# Altium schematic sheet KETCube.SchDoc
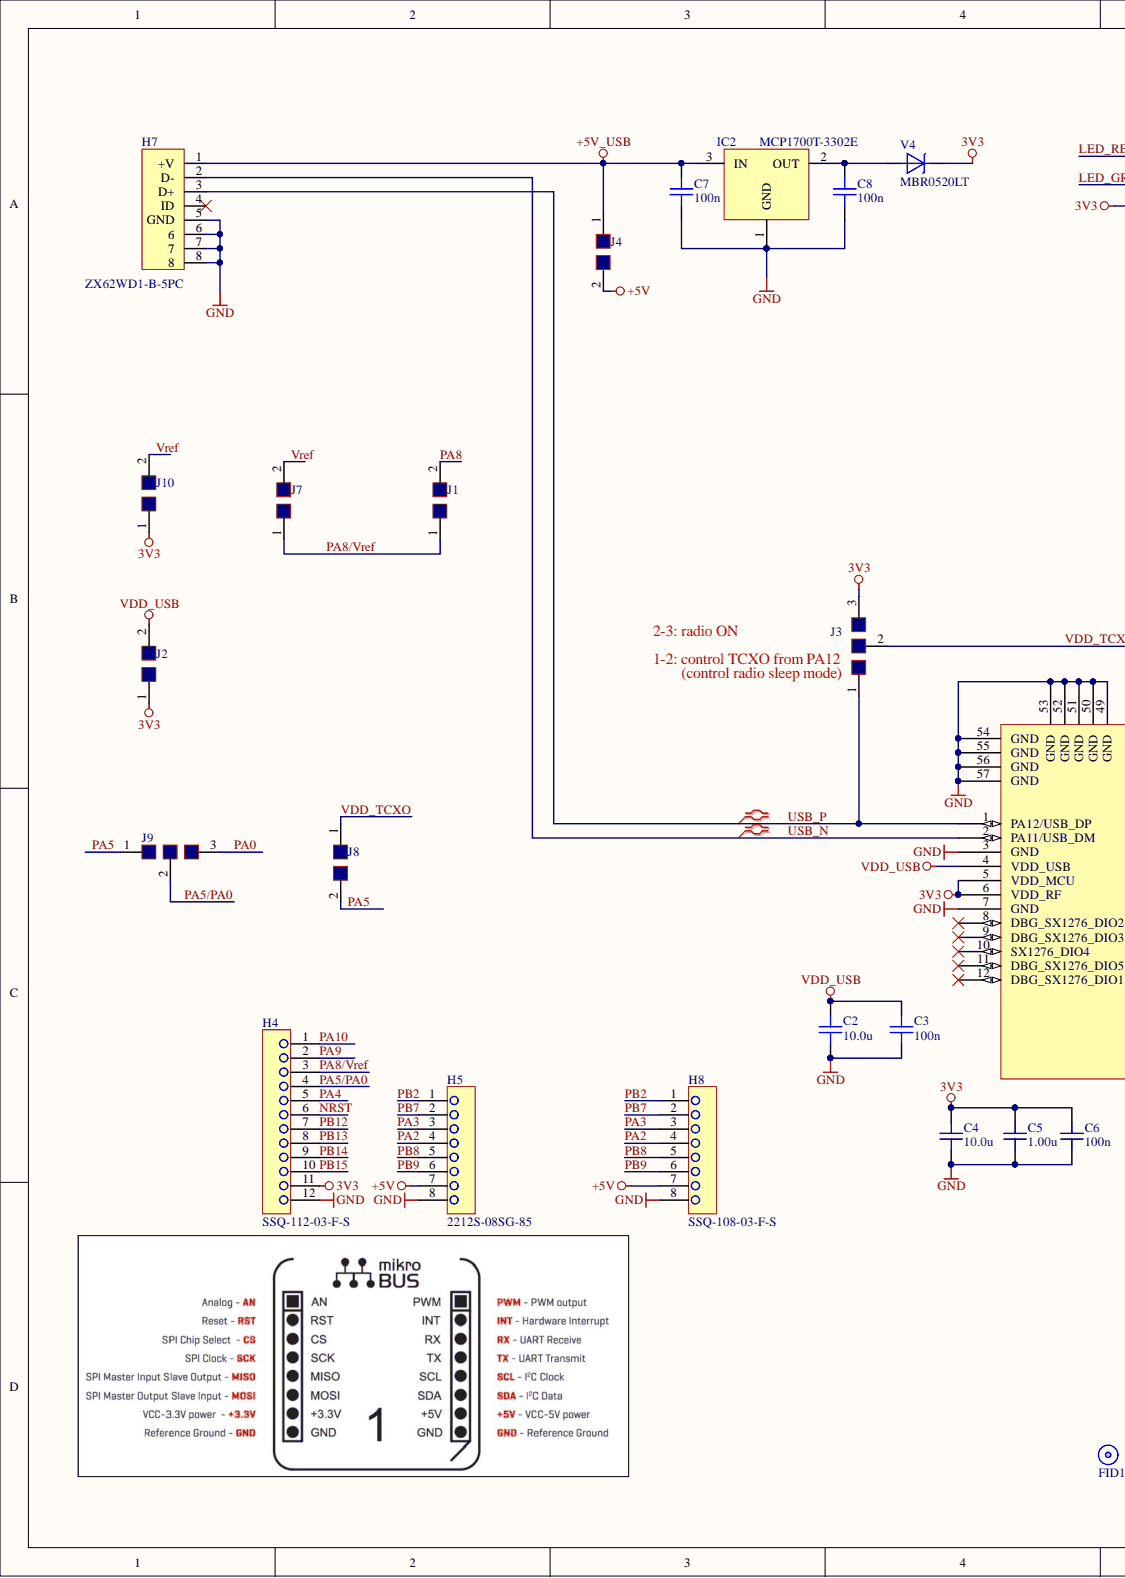
[diagram p0: KETCube.SchDoc, left]
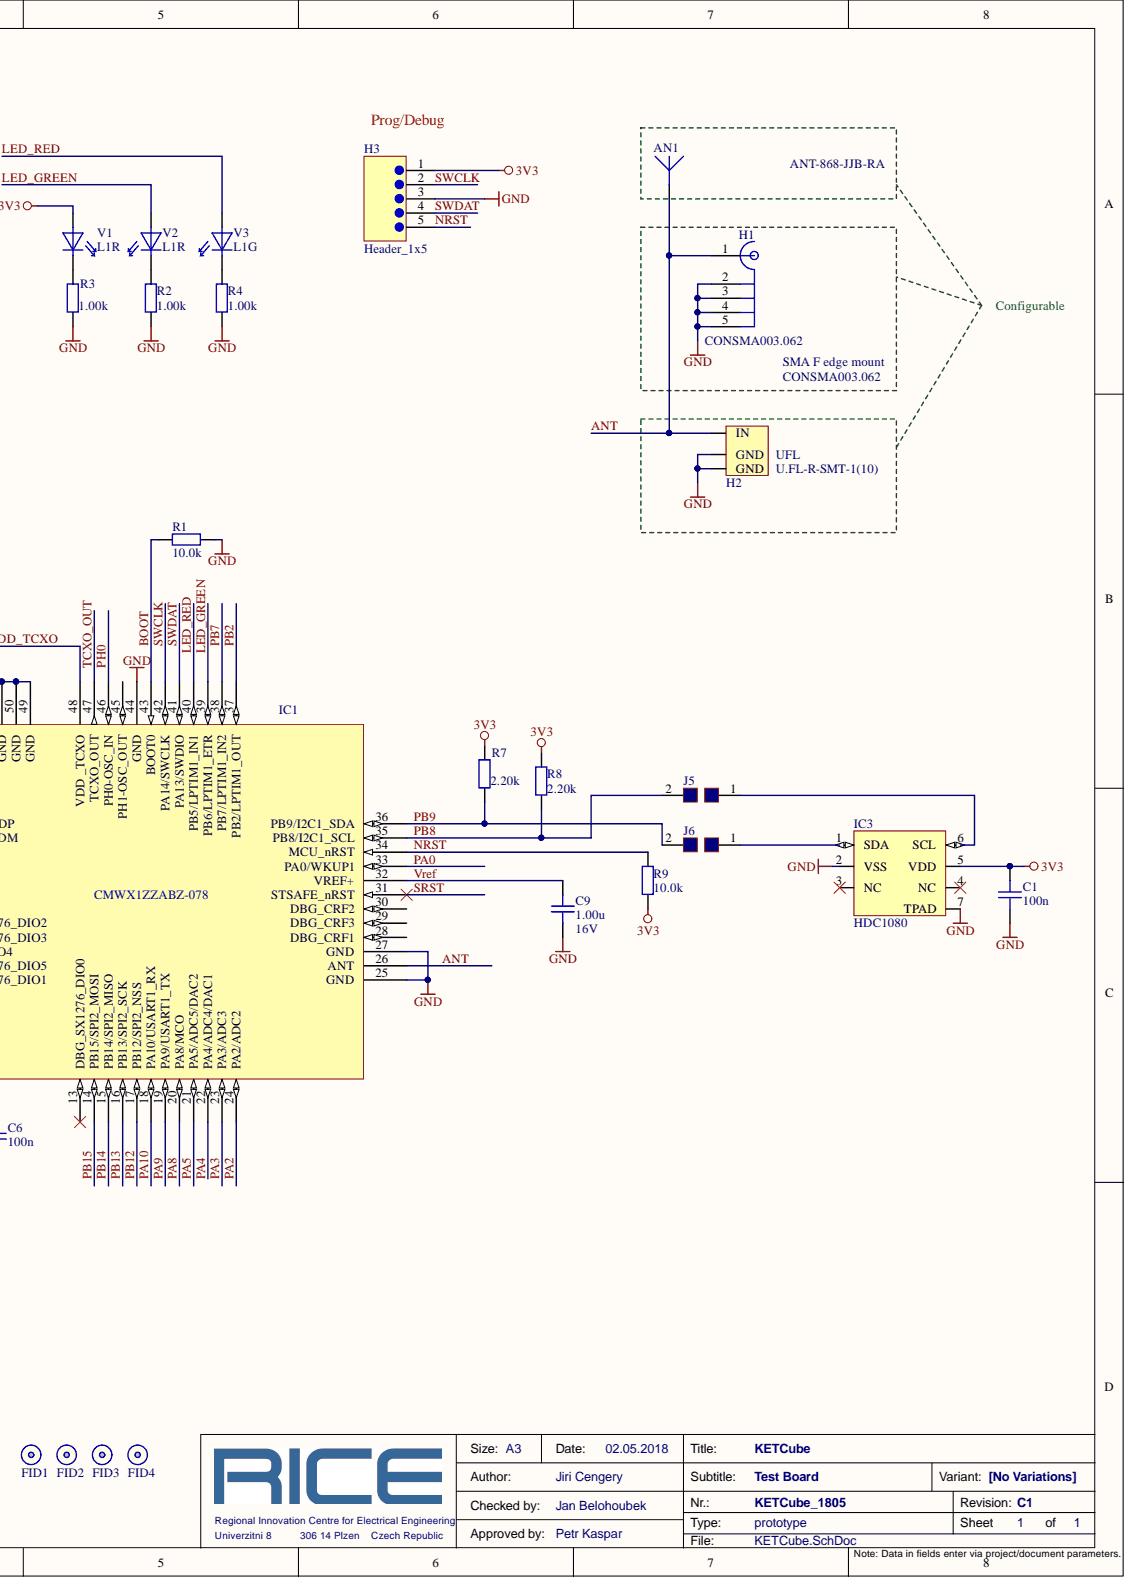
[diagram p1: KETCube.SchDoc, right]

## Parts
AN1  ANT-868-JJB-RA  [TH_ANT_868MHZ_90DEG]
C1  100n  [sm_cap_0603]
C2  10.0u  [sm_cap_0805]
C3  100n  [sm_cap_0603]
C4  10.0u  [sm_cap_0805]
C5  1.00u  [sm_cap_0603]
C6  100n  [sm_cap_0603]
C7  100n  [sm_cap_0603]
C8  100n  [sm_cap_0603]
C9  1.00u  Voltage: 16V  [sm_cap_0603]
FID1  Fiducial  [SM_mch_FIDUCIAL_32]  (value not drawn)
FID2  Fiducial  [SM_mch_FIDUCIAL_32]  (value not drawn)
FID3  Fiducial  [SM_mch_FIDUCIAL_32]  (value not drawn)
FID4  Fiducial  [SM_mch_FIDUCIAL_32]  (value not drawn)
H1  CONSMA003.062  [SM_CON_SMA_F_EDGE_LINX]  (value not drawn)
H2  U.FL-R-SMT-1  Manuf Part Number: U.FL-R-SMT-1(10)  [SM_CON_U.FL_R_SMT_1]
H3  Header_1x5  [TH_CON_HDR1X5_PIT50_RIGHT]
H4  SSQ-112-03-F-S  [TH_CON_REC1x12_PIT100_L400]
H5  2212S-08SG-85  [TH_CON_REC1x8_PIT100]
H7  ZX62WD1-B-5PC  [SM_CON_USB_Micro_B_ZX62WD1]
H8  SSQ-108-03-F-S  [TH_CON_REC1x8_PIT100_L400]
IC1  CMWX1ZZABZ-078  [TH_MOD_PIN48_LoRa_Murata]
IC2  MCP1700T-3302E  [sm_io_sot23_pin3]
IC3  HDC1080  [SM_IO_WSON6_PIT40]
J1  Solder jumper 0603  [SM_SOLDER_JUMPER_2PIN_0603]  (value not drawn)  (no BOM)
J2  Solder jumper 0603  [SM_SOLDER_JUMPER_2PIN_0603]  (value not drawn)  (no BOM)
J3  SMD jumper  [SM_SOLDER_JUMPER_3PIN]  (value not drawn)  (no BOM)
J4  Solder jumper 0603  [SM_SOLDER_JUMPER_2PIN_0603]  (value not drawn)  (no BOM)
J5  Solder jumper 0603  [SM_SOLDER_JUMPER_2PIN_0603]  (value not drawn)  (no BOM)
J6  Solder jumper 0603  [SM_SOLDER_JUMPER_2PIN_0603]  (value not drawn)  (no BOM)
J7  Solder jumper 0603  [SM_SOLDER_JUMPER_2PIN_0603]  (value not drawn)  (no BOM)
J8  Solder jumper 0603  [SM_SOLDER_JUMPER_2PIN_0603]  (value not drawn)  (no BOM)
J9  SMD jumper  [SM_SOLDER_JUMPER_3PIN]  (value not drawn)  (no BOM)
J10  Solder jumper 0603  [SM_SOLDER_JUMPER_2PIN_0603]  (value not drawn)  (no BOM)
R1  10.0k  [sm_res_0603]
R2  1.00k  [sm_res_0603]
R3  1.00k  [sm_res_0603]
R4  1.00k  [sm_res_0603]
R7  2.20k  [sm_res_0603]
R8  2.20k  [sm_res_0603]
R9  10.0k  [sm_res_0603]
V1  L1R  [sm_opt_led0603]
V2  L1R  [sm_opt_led0603]
V3  L1G  [sm_opt_led0603]
V4  MBR0520LT  [SM_DIO_SOD123]

## Nets
3V3: C1.2 C4.2 C5.2 C6.2 H3.1 H4.11 IC1.5(VDD_MCU) IC1.6(VDD_RF) IC3.5(VDD) J2.1 J3.3 J10.1 R7.2 R8.2 R9.1 V1.1 V4.2
+5V: H5.7 H8.7 J4.2
+5V_USB: C7.2 H7.1(+V) IC2.3 J4.1
GND: C1.1 C2.1 C3.1 C4.1 C5.1 C6.1 C7.1 C8.1 C9.1 H1.2 H1.3 H1.4 H1.5 H2.2 H2.3 H3.3 H4.12 H5.8 H7.5(GND) H7.6 H7.7 H7.8 H8.8 IC1.3(GND) IC1.7(GND) IC1.25(GND) IC1.27(GND) IC1.44(GND) IC1.49(GND) IC1.50(GND) IC1.51(GND) IC1.52(GND) IC1.53(GND) IC1.54(GND) IC1.55(GND) IC1.56(GND) IC1.57(GND) IC2.1 IC3.2(VSS) IC3.7(TPAD) R1.1 R2.2 R3.1 R4.2
VDD_USB: C2.2 C3.2 IC1.4(VDD_USB) J2.2
ANT: AN1.1 H1.1 H2.1 IC1.26(ANT)
BOOT: IC1.43(BOOT0) R1.2
LED_GREEN: IC1.39(PB6/LPTIM1_ETR) V2.1
LED_RED: IC1.40(PB5/LPTIM1_IN1) V3.1
NRST: H3.5 H4.6 IC1.34(MCU_nRST) R9.2
PA0: IC1.33(PA0/WKUP1) J9.3
PA2: H5.4 H8.4 IC1.24(PA2/ADC2)
PA3: H5.3 H8.3 IC1.23(PA3/ADC3)
PA4: H4.5 IC1.22(PA4/ADC4/DAC1)
PA5: IC1.21(PA5/ADC5/DAC2) J8.2 J9.1
PA5/PA0: H4.4 J9.2
PA8: IC1.20(PA8/MCO) J1.2
PA8/Vref: H4.3 J1.1 J7.1
PA9: H4.2 IC1.19(PA9/USART1_TX)
PA10: H4.1 IC1.18(PA10/USART1_RX)
PB2: H5.1 H8.1 IC1.37(PB2/LPTIM1_OUT)
PB7: H5.2 H8.2 IC1.38(PB7/LPTIM1_IN2)
PB8: H5.5 H8.5 IC1.35(PB8/I2C1_SCL) J5.2 R8.1
PB9: H5.6 H8.6 IC1.36(PB9/I2C1_SDA) J6.2 R7.1
PB12: H4.7 IC1.17(PB12/SPI2_NSS)
PB13: H4.8 IC1.16(PB13/SPI2_SCK)
PB14: H4.9 IC1.15(PB14/SPI2_MISO)
PB15: H4.10 IC1.14(PB15/SPI2_MOSI)
PH0: IC1.46(PH0-OSC_IN)
SRST: IC1.31(STSAFE_nRST)
SWCLK: H3.2 IC1.42(PA14/SWCLK)
SWDAT: H3.4 IC1.41(PA13/SWDIO)
TCXO_OUT: IC1.47(TCXO_OUT)
USB_N: H7.2(D-) IC1.2(PA11/USB_DM)
USB_P: H7.3(D+) IC1.1(PA12/USB_DP) J3.1
VDD_TCXO: IC1.48(VDD__TCXO) J3.2 J8.1
Vref: C9.2 IC1.32(VREF+) J7.2 J10.2
NetC8_2: C8.2 IC2.2 V4.1
NetIC3_1: IC3.1(SDA) J6.1
NetIC3_6: IC3.6(SCL) J5.1
NetR2_1: R2.1 V2.2
NetR3_2: R3.2 V1.2
NetR4_1: R4.1 V3.2

Not connected: H7 4(ID); IC1 8(DBG_SX1276_DIO2) 9(DBG_SX1276_DIO3) 10(SX1276_DIO4) 11(DBG_SX1276_DIO5) 12(DBG_SX1276_DIO1) 13(DBG_SX1276_DIO0) 28(DBG_CRF1) 29(DBG_CRF3) 30(DBG_CRF2) 45(PH1-OSC_OUT); IC3 3(NC) 4(NC)

## Library data of the parts
AN1 = MOD_000026: MOD Antenna, PCB mount, Perm Mnt Mini, 90deg, 868MHz; Manufacturer: Linx Technologies; ManufacturerID: Linx; Part Select: TMOD0006000000000000; Supp Part number: 712-ANT-868-JJB-RA; Active: 1; Database Table Name: _Modules; Designer: Lukas Pusman; Exact price 2: 0; FIT: 3; ID: 4530; LasrRevisionNote: change designator to AN; Max Oper Temperature: 90; Min Oper Temperature: -40; Alternative: 1; Cat1: Antenna; Component Kind: Standard; ComponentLink1Description: Datasheet; ComponentLink1URL: https://riceproject.fel.zcu.cz/Altium/PDF/Passive\antennas\Linx\ANT-868-JJB-xx_DataSheet_131209-291227.pdf; ComponentLink2Description: PCB Antenna; Exact price: 1; Exaxt price 3: 0; LastRevisionDate: 2.7.2013; Library Name: Lib_Components_SQL.DbLib; ManufacturerURL: https://www.linxtechnologies.com; Manuf Part Number: ANT-868-JJB-RA; Part Category: Antenna; Part Number: MOD_000026; PartNumber: MOD_000026; PartQuantity: 1; Part type: MOD; Preference: 0; Price: 54; Price 2: 0; Price 3: 0; Publishied: 11.6.2013; ROHS: 1; Simulation: nosim; Stock: 0; Supplier: Mouser Electronics; Supplier2: N/A; Supplier3: N/A; SupplierID: Mouser; SupplierID2: no supplier; SupplierID3: no supplier; SupplierURL: www.mouser.com; SupplierURL2: N/A; SupplierURL3: N/A; Value: ANT-868-JJB-RA; Publisher: Jiri Zahour
C1 C3 C6-C8 = CAP_000000: CAP CER .10UF 50V X7R 10% 0603; Manufacturer: TDK Corporation; ManufacturerID: TDK; Manuf Part Number: C1608X7R1H104K080AA; Part Category: Ceramic/0603/X7R; Part Select: SCAP0001000300026100; Supp Part number: 445-1314-2-ND; Supp Part number 2: 1907318; Active: 1; Cat1: Ceramic; Cat2: 0603; Database Table Name: _Capacitors; Designer: Jiri  Cengery; Exact price 2: 0; FIT: 0,100000001490116; ID: 4; LasrRevisionNote: new release; Max Oper Temperature: 125; Min Oper Temperature: -55; PCB Status: Electrical; Preference: 100; Alternative: 1; Cat3: X7R; Component Kind: Standard; ComponentLink1Description: Datasheet; ComponentLink1URL: https://riceproject.fel.zcu.cz/Altium/PDF/passive\capacitors\Cxxxx_TDK.pdf; Dielectric: X7R; Exact price: 1; Exaxt price 3: 0; LastRevisionDate: 11.5.2011; Library Name: Lib_Components_SQL.DbLib; ManufacturerURL: http://www.tdk.com/; Part Number: CAP_000000; PartNumber: CAP_000000; PartQuantity: 1; Part type: CAP; PCB3D: sm_cap_0603; Price: 0,112099997699261; Price 2: 0,899999976158142; Price 3: 0; Publishied: 11.5.2011; Quantity: 1; ROHS: 1; Simulation: cap; Stock: 2901; Supplier: Digikey; Supplier2: Farnell; Supplier3: N/A; SupplierID: Digikey; SupplierID2: Farnell; SupplierID3: no supplier; SupplierURL: http://www.digikey.com/; SupplierURL2: http://cz.farnell.com/; SupplierURL3: N/A; Tolerance: 10%; Value: 100n; Voltage: 50V; Publisher: Libor Polacek
C2 C4 = CAP_000438: CAP CER 10.0u 16V X6S 10% 105°C 0805; Manufacturer: TDK Corporation; ManufacturerID: TDK; Manuf Part Number: C2012X6S1C106K125AC; Part Category: Ceramic/0805/X6S; Part Select: SCAP0001000400038100; Supp Part number: 2346933; Supp Part number 2: 810-C2012X6S1C106K1C; Supp Part number 3: 445-7611-1-ND; Active: 1; Cat1: Ceramic; Cat2: 0805; Database Table Name: _Capacitors; Designer: Jindrich Krivka; FIT: 0,100000001490116; ID: 2834; LastRevisionNote: new release; Max Oper Temperature: 105; Min Oper Temperature: -55; PCB Status: Electrical; Preference: 0; Alternative: 1; Cat3: X6S; Component Kind: Standard; ComponentLink1Description: Datasheet; ComponentLink1URL: https://riceproject.fel.zcu.cz/Altium/PDF/Passive\Capacitors\TDK_mlcc_C_Comercial_Grade.pdf; Dielectric: X6S; Exact price: 1; Exact price 2: 1; Exaxt price 3: 1; LastRevisionDate: 11.5.2011; Library Name: Lib_Components_SQL.DbLib; ManufacturerURL: http://www.tdk.com/; Part Number: CAP_000438; PartNumber: CAP_000438; PartQuantity: 1; Part type: CAP; Price: 5,67999982833862; Price 2: 8,60000038146973; Price 3: 8,30000019073486; Published: 11.5.2011; ROHS: 1; Simulation: cap; Stock: 0; Supplier: Farnell; Supplier2: Mouser Electronics; Supplier3: Digikey; SupplierID: Farnell; SupplierID2: Mouser; SupplierID3: Digikey; SupplierURL: http://cz.farnell.com/; SupplierURL2: www.mouser.com; SupplierURL3: http://www.digikey.com/; Tolerance: 10%; Value: 10.0u; Voltage: 16V; Publisher: Libor Polacek
C5 C9 = CAP_000381: CAP CER 1.0UF 16V X7R 10% 0603; Manufacturer: TDK Corporation; ManufacturerID: TDK; Manuf Part Number: C1608X7R1C105K; Part Category: Ceramic/0603/X7R; Part Select: SCAP0001000300027100; Supp Part number: 445-1604-1-ND; Supp Part number 2: 1907343; Active: 1; Cat1: Ceramic; Cat2: 0603; Database Table Name: _Capacitors; Designer: Jiri  Cengery; Exact price 2: 0; FIT: 0,100000001490116; ID: 1567; LastRevisionNote: new release; Max Oper Temperature: 125; Min Oper Temperature: -55; PCB Status: Electrical; Alternative: 1; Cat3: X7R; Component Kind: Standard; ComponentLink1Description: Datasheet; ComponentLink1URL: https://riceproject.fel.zcu.cz/Altium/PDF/Passive\Capacitors\C_series_TDK.pdf; Dielectric: X7R; Exact price: 1; Exaxt price 3: 0; LastRevisionDate: 11.5.2011; Library Name: Lib_Components_SQL.DbLib; ManufacturerURL: http://www.tdk.com/; Part Number: CAP_000381; PartNumber: CAP_000381; PartQuantity: 1; Part type: CAP; PCB3D: sm_cap_0603; Preference: 0; Price: 1,10000002384186; Price 2: 25; Price 3: 0; Published: 11.5.2011; ROHS: 1; Simulation: cap; Stock: 3184; Supplier: Digikey; Supplier2: Farnell; Supplier3: N/A; SupplierID: Digikey; SupplierID2: Farnell; SupplierID3: no supplier; SupplierURL: http://www.digikey.com/; SupplierURL2: http://cz.farnell.com/; SupplierURL3: N/A; Tolerance: 10%; Value: 1.00u; Publisher: Libor Polacek
  C5: Voltage: 16V
FID1-FID4 = MCH_000050: Fiducial local circle 0.8mm; ManufacturerID: no manufacturer; Manuf Part Number: x; Part Category: Virtual/Fiducial; Part Select: SMCH0002000200000000; Active: 1; Cat1: Virtual; Database Table Name: _Mechanicals; Designer: Libor Polacek; Exact price: 0; ID: 2608; LastRevisionNote: new release; Max Oper Temperature: 200; Min Oper Temperature: -60; Alternative: 1; Cat2: Fiducial; Component Kind: Standard; ComponentLink1Description: Datasheet; Exact price 2: 0; Exaxt price 3: 0; FIT: 0; Function Type: fiducial; LastRevisionDate: 8.9.2011; Library Name: Lib_Components_SQL.DbLib; Part Number: MCH_000050; PartNumber: MCH_000050; PartQuantity: 1; Part type: MCH; PCB3D: sm_vir_fiducial; PCB Status: Virtual; Preference: 0; Price: 0; Price 2: 0; Price 3: 0; Publishied: 8.9.2011; ROHS: 1; Simulation: nosim; Stock: 0; Supplier: N/A; Supplier2: N/A; Supplier3: N/A; SupplierID: no supplier; SupplierID2: no supplier; SupplierID3: no supplier; SupplierURL: N/A; SupplierURL2: N/A; SupplierURL3: N/A; Supp Part number: x; Value: Fiducial; Publisher: Libor Polacek
H1 = CON_000304: SMA; Manufacturer: Lynx Technologies; Part Select: SCON0008000000001500; Supp Part number: CONSMA003.062-ND; Supp Part number 2: 712-CONSMA003.062; Active: 1; Cat1: VF; Database Table Name: _Connectors; Design: SMA Female  edge mount; Designer: Jiri  Cengery; Exact price 2: 0; ID: 4582; LastRevisionNote: new release; Max Oper Temperature: 165; Min Oper Temperature: -65; PCB Status: Electrical; Alternative: 1; Component Kind: Standard; ComponentLink1Description: Datasheet; ComponentLink1URL: https://riceproject.fel.zcu.cz/Altium/PDF/Mechanic\CONSMA003_062.pdf; ComponentLink2Description: SMA connector; Exact price: 1; Exaxt price 3: 0; FIT: 0; LastRevisionDate: 11.11.2013; Library Name: Lib_Components_SQL.DbLib; ManufacturerID: Lynx Technologies; ManufacturerURL: www.linxtechnologies.com; Manuf Part Number: CONSMA003.062; Part Category: VF; Part Number: CON_000304; PartNumber: CON_000304; PartQuantity: 1; Part type: CON; Pin: 5; Preference: 0; Price: 75; Price 2: 84; Price 3: 0; Published: 29.5.2013; ROHS: 1; Simulation: nosim; Stock: 0; Supplier: Digikey; Supplier2: N/A; Supplier3: N/A; SupplierID: Digikey; SupplierID2: no supplier; SupplierID3: no supplier; SupplierURL: http://www.digikey.com/; SupplierURL2: N/A; SupplierURL3: N/A; Value: CONSMA003.062; Publisher: Jiri Svarny
H2 = CON_000300: CON 3pin, Ultra Small Surface Mount Coaxial Connectors, up to 6GHz; Manufacturer: Hirose U.S.A.; ManufacturerID: Hirose; Part Select: SCON0008000000001300; Supp Part number: 1688077; Active: 1; Cat1: VF; Database Table Name: _Connectors; Design: smt; Designer: Jiri  Cengery; Exact price 2: 0; ID: 4492; LastRevisionNote: new realese; Max Oper Temperature: 120; Min Oper Temperature: -30; PCB Status: Electrical; Alternative: 1; Component Kind: Standard; ComponentLink1Description: Datasheet; ComponentLink1URL: https://riceproject.fel.zcu.cz/Altium/PDF/Passive\Connectors\Hirose\1947917.pdf; Exact price: 1; Exaxt price 3: 0; FIT: 0; LastRevisionDate: 1.9.2016; Library Name: Lib_Components_SQL.DbLib; ManufacturerURL: http://www.hiroseusa.com/; Part Category: VF; Part Number: CON_000300; PartNumber: CON_000300; PartQuantity: 1; Part type: CON; Pin: 3; Preference: 0; Price: 18; Price 2: 0; Price 3: 0; Published: 1.9.2016; ROHS: 1; Simulation: nosim; Stock: 0; Supplier: Farnell; Supplier2: N/A; Supplier3: N/A; SupplierID: Farnell; SupplierID2: no supplier; SupplierID3: no supplier; SupplierURL: http://cz.farnell.com/; SupplierURL2: N/A; SupplierURL3: N/A; Value: U.FL-R-SMT-1; Publisher: Jiri Cengery
H3 = CON_000371: CON 1x5pin header 50mill, righ , L 4mm; Manufacturer: SAMTEC; Manuf Part Number: TMS-105-02-L-S-RA; Part Category: Pin rows/Header PIT50/Position; Part Select: TCON0001000600015001; Supp Part number: 2055114; Active: 1; Cat1: Pin rows; Cat2: Header PIT50; Cat3: Position; Database Table Name: _Connectors; Designer: Jiri  Cengery; Exact price 2: 0; FIT: 5; ID: 5632; Max Oper Temperature: 105; Min Oper Temperature: -40; PCB Status: Electrical; Alternative: 1; Component Kind: Standard; ComponentLink1Description: Datasheet; ComponentLink1URL: https://riceproject.fel.zcu.cz/Altium/PDF/Passive\Connectors\Samtec\1992060.pdf; Design: HEADER 50mill; Exact price: 1; Exaxt price 3: 0; LatestRevisionDate: 09-Sep-2011; LatestRevisionNote: Re-released for DXP Platform.; Library Name: Lib_Components_SQL.DbLib; ManufacturerID: SAMTEC; ManufacturerURL: http://www.samtec.com/; Part Number: CON_000371; PartNumber: CON_000371; PartQuantity: 1; Part type: CON; Pin: 5; Preference: 0; Price: 14; Price 2: 0; Price 3: 0; Published: 15.6.2011; ROHS: 1; Signal Integrity: Connector; Simulation: nosim; Stock: 0; Supplier: Farnell; Supplier2: N/A; Supplier3: N/A; SupplierID: Farnell; SupplierID2: no supplier; SupplierID3: no supplier; SupplierURL: http://cz.farnell.com/; SupplierURL2: N/A; SupplierURL3: N/A; Value: Header_1x5; Publisher: Altium Limited
H4 = CON_000361: CON Receptacle 1x10 100 mils, leads length 10mm; Manufacturer: SAMTEC; Part Category: Pin rows/Receptacle/long leads; Part Select: TCON0001000200022120; Supp Part number: 2283784; Active: 1; Cat1: Pin rows; Cat3: long leads; Database Table Name: _Connectors; Design: RECEPTACLE 100mill; Designer: Jiri  Cengery; Exact price 2: 0; FIT: 50; ID: 5578; Max Oper Temperature: 125; Min Oper Temperature: -55; PCB Status: Electrical; System: Connector; Alternative: 1; Cat2: Receptacle; Component Kind: Standard; ComponentLink1Description: Datasheet; ComponentLink1URL: https://riceproject.fel.zcu.cz/Altium/PDF/Passive\Connectors\Samtec\1680445_.pdf; Exact price: 1; Exaxt price 3: 0; LatestRevisionDate: 13.10.2017; LatestRevisionNote: New Release; Library Name: Lib_Components_SQL.DbLib; ManufacturerID: SAMTEC; ManufacturerURL: http://www.samtec.com/; Manuf Part Number: SSQ-112-03-F-S; Part Number: CON_000361; PartNumber: CON_000361; PartQuantity: 1; Part type: CON; PCB3D: TH_CON_REC1x12_PIT100_L400; Pin: 12; Preference: 0; Price: 54; Price 2: 0; Price 3: 0; Published: 13.10.2017; ROHS: 1; Signal Integrity: Connector; Simulation: nosim; Stock: 0; Supplier: Farnell; Supplier2: N/A; Supplier3: N/A; SupplierID: Farnell; SupplierID2: no supplier; SupplierID3: no supplier; SupplierURL: http://cz.farnell.com/; SupplierURL2: N/A; SupplierURL3: N/A; Value: SSQ-112-03-F-S; Publisher: Jiri Cengery
H5 = CON_000370: CON Receptacle 1x8 100 mils, hight 8.5mm; Manufacturer: MCM Electronics; ManufacturerID: Multicomp; Part Category: Pin rows/Receptacle; Part Select: TCON0001000200001800; Supp Part number: 1593463; Active: 1; Cat1: Pin rows; Database Table Name: _Connectors; Design: RECEPTACLE 100mill; Designer: Jiri  Cengery; Exact price 2: 0; FIT: 50; ID: 5624; Max Oper Temperature: 105; Min Oper Temperature: -55; PCB Status: Electrical; System: Connector; Alternative: 1; Cat2: Receptacle; Component Kind: Standard; ComponentLink1Description: Datasheet; ComponentLink1URL: https://riceproject.fel.zcu.cz/Altium/PDF/Passive\Connectors\2290158.pdf; Exact price: 1; Exaxt price 3: 0; LatestRevisionDate: 13.10.2017; LatestRevisionNote: New Release; Library Name: Lib_Components_SQL.DbLib; ManufacturerURL: http://www.mcmelectronics.com; Manuf Part Number: 2212S-08SG-85; Part Number: CON_000370; PartNumber: CON_000370; PartQuantity: 1; Part type: CON; PCB3D: TH_CON_REC1x8_PIT100; Pin: 8; Preference: 0; Price: 23; Price 2: 0; Price 3: 0; Published: 13.10.2017; ROHS: 1; Signal Integrity: Connector; Simulation: nosim; Stock: 0; Supplier: Farnell; Supplier2: N/A; Supplier3: N/A; SupplierID: Farnell; SupplierID2: no supplier; SupplierID3: no supplier; SupplierURL: http://cz.farnell.com/; SupplierURL2: N/A; SupplierURL3: N/A; Value: 2212S-08SG-85; Publisher: Jiri Cengery
H7 = CON_000356: CON USB micro B, USB 2; 5 pins; righ angle, PCB mount SMT; Manufacturer: Hirose U.S.A.; ManufacturerID: Hirose; Part Category: Comunication/USB; Part Select: SCON0006000100001500; Supp Part number: 2427509; Active: 1; Cat1: Comunication; Database Table Name: _Connectors; Designer: Jiri  Cengery; Exact price: 0; FIT: 4; ID: 5438; LastRevisionNote: new release; Max Oper Temperature: 85; Min Oper Temperature: -40; PCB Status: Electrical; Alternative: 1; Cat2: USB; Component Kind: Standard; ComponentLink1Description: Datasheet; ComponentLink1URL: https://riceproject.fel.zcu.cz/Altium/PDF/Passive\Connectors\Hirose\ZX_02420121300_2d.pdf; Design: USB micro B; Exact price 2: 0; Exaxt price 3: 0; LastRevisionDate: 17.8.2017; Library Name: Lib_Components_SQL.DbLib; ManufacturerURL: http://www.hiroseusa.com/; Manuf Part Number: ZX62WD1-B-5PC; Part Number: CON_000356; PartNumber: CON_000356; PartQuantity: 1; Part type: CON; PCB3D: SM_CON_USB_Micro_B_ZX62WD1; Pin: 5; Preference: 0; Price: 33; Price 2: 0; Price 3: 0; Published: 17.8.2017; ROHS: 1; Simulation: nosim; Stock: 0; Supplier: Farnell; Supplier2: N/A; Supplier3: N/A; SupplierID: Farnell; SupplierID2: no supplier; SupplierID3: no supplier; SupplierURL: http://cz.farnell.com/; SupplierURL2: N/A; SupplierURL3: N/A; Value: ZX62WD1-B-5PC; Publisher: Jiri Cengery
H8 = CON_000358: CON Receptacle 1x8 100 mils, leads length 10mm; Manufacturer: SAMTEC; Part Category: Pin rows/Receptacle/long leads; Part Select: TCON0001000200021800; Supp Part number: 2505051; Active: 1; Cat1: Pin rows; Cat3: long leads; Database Table Name: _Connectors; Design: RECEPTACLE 100mill; Designer: Jiri  Cengery; Exact price 2: 0; FIT: 50; ID: 5560; Max Oper Temperature: 125; Min Oper Temperature: -55; PCB Status: Electrical; System: Connector; Alternative: 1; Cat2: Receptacle; Component Kind: Standard; ComponentLink1Description: Datasheet; ComponentLink1URL: https://riceproject.fel.zcu.cz/Altium/PDF/Passive\Connectors\Samtec\1680445_.pdf; Exact price: 1; Exaxt price 3: 0; LatestRevisionDate: 13.10.2017; LatestRevisionNote: New Release; Library Name: Lib_Components_SQL.DbLib; ManufacturerID: SAMTEC; ManufacturerURL: http://www.samtec.com/; Manuf Part Number: SSQ-108-03-F-S; Part Number: CON_000358; PartNumber: CON_000358; PartQuantity: 1; Part type: CON; PCB3D: TH_CON_REC1x8_PIT100_L400; Pin: 8; Preference: 0; Price: 38; Price 2: 0; Price 3: 0; Published: 13.10.2017; ROHS: 1; Signal Integrity: Connector; Simulation: nosim; Stock: 0; Supplier: Farnell; Supplier2: N/A; Supplier3: N/A; SupplierID: Farnell; SupplierID2: no supplier; SupplierID3: no supplier; SupplierURL: http://cz.farnell.com/; SupplierURL2: N/A; SupplierURL3: N/A; Value: SSQ-108-03-F-S; Publisher: Jiri Cengery
IC1 = MOD_000027: Lora modul Murata (STM32L, 868 MHz, 915 MHz) 12 x 12 mm; Part Category: Comunication/Proprietary; Part Select: SMOD0001000600000000; Supp Part number: 81-CMWX1ZZABZ-078; Active: 1; Cat1: Comunication; Cat2: Proprietary; Database Table Name: _Modules; Designer: Jiri  Cengery; Exact price: 0; FIT: 3; ID: 4764; LasrRevisionNote: new release; Max Oper Temperature: 85; Min Oper Temperature: -40; Alternative: 1; Component Kind: Standard; ComponentLink1Description: Datasheet; ComponentLink1URL: https://riceproject.fel.zcu.cz/Altium/PDF/Semiconductor\Modules\Murata\Murata LoRa Module Preliminary Datasheet SP-ABZ-G.PDF; ComponentLink2Description: Lora modul; Exact price 2: 0; Exaxt price 3: 0; LastRevisionDate: 10.1.2017; Library Name: Lib_Components_SQL.DbLib; Manufacturer: Murata; ManufacturerID: Murata; ManufacturerURL: http://www.murata.com/; Manuf Part Number: CMWX1ZZABZ-078; Part Number: MOD_000027; PartNumber: MOD_000027; PartQuantity: 1; Part type: MOD; Preference: 0; Price: 523; Price 2: 0; Price 3: 0; Publishied: 10.1.2017; ROHS: 1; Simulation: nosim; Stock: 0; Supplier: Mouser Electronics; Supplier2: N/A; Supplier3: N/A; SupplierID: Mouser; SupplierID2: no supplier; SupplierID3: no supplier; SupplierURL: www.mouser.com; SupplierURL2: N/A; SupplierURL3: N/A; Value: CMWX1ZZABZ-078; Publisher: Jiri Cengery
IC2 = ICS_000567: ICS LDO regulator Vout=3.3V, 250mA, Vin=6V, SOT23-3, Vdrop =  200mV; Manufacturer: Microchip; Manuf Part Number: MCP1700T-3302E/TT; Part Category: Analog/Regulator; Part Select: SICS0001000700000000; Supp Part number: 1296592; Active: 1; Cat1: Analog; Database Table Name: _ICs; Designer: Jiri  Cengery; Exact price 2: 0; ID: 4558; LastRevisionNote: new release; Marking: CKCS; Max Oper Temperature: 125; Min Oper Temperature: -40; PCB Status: Electrical; Alternative: 1; Cat2: Regulator; Component Kind: Standard; ComponentLink1Description: Datasheet; ComponentLink1URL: https://riceproject.fel.zcu.cz/Altium/PDF/Semiconductor\Analog\Regulator\1784514.pdf; Exact price: 1; Exaxt price 3: 0; FIT: 1; Function Type: Regulator; LastRevisionDate: 23.9.2016; Library Name: Lib_Components_SQL.DbLib; ManufacturerID: Microchip; ManufacturerURL: http://www.microchip.com/; Part Number: ICS_000567; PartNumber: ICS_000567; PartQuantity: 1; Part type: ICS; Preference: 0; Price: 0; Price 2: 0; Price 3: 0; Published: 23.9.2016; ROHS: 1; Simulation: nosim; Stock: 0; Supplier: Farnell; Supplier2: N/A; Supplier3: N/A; SupplierID: Farnell; SupplierID2: no supplier; SupplierID3: no supplier; SupplierURL: http://cz.farnell.com/; SupplierURL2: N/A; SupplierURL3: N/A; Value: MCP1700T-3302E; Publisher: Jiri Cengery
IC3 = ICS_000724: ICS Low Power, High Accuracy Digital Humidity Sensor with Temperature Sensor; Manufacturer: Texas Instruments; ManufacturerID: TI; Manuf Part Number: HDC1080DMBT; Part Category: Digital/sensor/T+RH; Part Select: SICS0002001600040000; Supp Part number: 2535017; Supp Part number 2: 296-43864-1-ND; Active: 1; Cat3: T+RH; Database Table Name: _ICs; Designer: Jiri  Cengery; Exact price 2: 0; FIT: 500; Function Type: Temperature and RH sensor; ID: 5552; LastRevisionNote: new release; Max Oper Temperature: 125; Min Oper Temperature: -40; PCB Status: Electrical; Alternative: 1; Cat1: Digital; Cat2: sensor; Component Kind: Standard; ComponentLink1Description: Datasheet; ComponentLink1URL: https://riceproject.fel.zcu.cz/Altium/PDF/Semiconductor\Modules\Sensors\hdc1080.pdf; Exact price: 1; Exaxt price 3: 0; LastRevisionDate: 19.2.2016; Library Name: Lib_Components_SQL.DbLib; ManufacturerURL: http://www.ti.com/; Part Number: ICS_000724; PartNumber: ICS_000724; PartQuantity: 1; Part type: ICS; Preference: 0; Price: 78; Price 2: 90; Price 3: 0; Publish: 19.2.2016; ROHS: 1; Simulation: nosim; Stock: 0; Supplier: Farnell; Supplier2: Digikey; Supplier3: N/A; SupplierID: Farnell; SupplierID2: Digikey; SupplierID3: no supplier; SupplierURL: http://cz.farnell.com/; SupplierURL2: http://www.digikey.com/; SupplierURL3: N/A; Technology: CMOS; Value: HDC1080; Publisher: Jiri Cengery
J1 J2 J4-J8 J10 = MCH_000103: Solder jumper 2pin; simple type; ManufacturerID: no manufacturer; Part Category: Virtual/Pattern; Part Select: SMCH0002000300000000; Active: 1; Cat1: Virtual; Cat2: Pattern; Database Table Name: _Mechanicals; Designer: Jiri  Cengery; Exact price 2: 0; FIT: 9,99999974737875E-6; ID: 5562; Max Oper Temperature: 125; Min Oper Temperature: -45; Alternative: 1; ComponentLink1Description: Datasheet; Exact price: 1; Exaxt price 3: 0; Function Type: Solder jumper; LatestRevisionDate: 22.1.2012; LatestRevisionNote: new release; Library Name: Lib_Components_SQL.DbLib; Part Number: MCH_000103; PartNumber: MCH_000103; PartQuantity: 1; Part type: MCH; PCB Status: Virtual; Preference: 0; Price: 0; Price 2: 0; Price 3: 0; Published: 22.1.2012; ROHS: 1; Simulation: nosim; Stock: 0; Supplier: N/A; Supplier2: N/A; Supplier3: N/A; SupplierID: no supplier; SupplierID2: no supplier; SupplierID3: no supplier; SupplierURL: N/A; SupplierURL2: N/A; SupplierURL3: N/A; Value: Solder jumper 0603; Publisher: Tomas Kosan
J3 J9 = MCH_000104: Solder jumper 2pin; simple type; ManufacturerID: no manufacturer; Part Category: Virtual/Pattern; Part Select: SMCH0002000300000000; Active: 1; Cat1: Virtual; Cat2: Pattern; Database Table Name: _Mechanicals; Designer: Jiri  Cengery; Exact price 2: 0; FIT: 9,99999974737875E-6; Function Type: Solder jumper 3PIN; ID: 5870; Max Oper Temperature: 125; Min Oper Temperature: -45; Value: Solder jumper 3PIN small; Alternative: 1; ComponentLink1Description: Datasheet; Exact price: 1; Exaxt price 3: 0; LatestRevisionDate: 22.1.2012; LatestRevisionNote: new release; Library Name: Lib_Components_SQL.DbLib; Part Number: MCH_000104; PartNumber: MCH_000104; PartQuantity: 1; Part type: MCH; PCB Status: Virtual; Preference: 0; Price: 0; Price 2: 0; Price 3: 0; Published: 22.1.2012; ROHS: 1; Simulation: nosim; Stock: 0; Supplier: N/A; Supplier2: N/A; Supplier3: N/A; SupplierID: no supplier; SupplierID2: no supplier; SupplierID3: no supplier; SupplierURL: N/A; SupplierURL2: N/A; SupplierURL3: N/A; Publisher: Tomas Kosan
R1 R9 = RES_000097: RES 0603 10.0k 1% 100ppm SMD thick film; Manufacturer: Panasonic; Manuf Part Number: ERJ3EKF1002V; Part Category: Thick film/0603; Part Select: SRES0001000300005100; Supp Part number: P10.0kHTR-ND; Supp Part number 2: 667-ERJ-3EKF1002V; Active: 1; Cat2: 0603; Database Table Name: _Resistors; Designer: Tomáš Košan; Exact price 2: 0; FIT: 0,100000001490116; ID: 458; LastRevisionNote: new release; Max Oper Temperature: 125; Min Oper Temperature: -55; PCB Status: Electrical; Power: 63mW; Alternative: 1; Cat1: Thick film; Component Kind: Standard; ComponentLink1Description: Datasheet; ComponentLink1URL: https://riceproject.fel.zcu.cz/Altium/PDF/passive\resistors\ERJ_EKF_Panasonic.pdf; Exact price: 1; Exaxt price 3: 0; LastRevisionDate: 11.5.2011; Library Name: Lib_Components_SQL.DbLib; ManufacturerID: Panasonic; ManufacturerURL: http://www.industrial.panasonic.com/; Part Number: RES_000097; PartNumber: RES_000097; PartQuantity: 1; Part type: RES; PCB3D: sm_res_0603; Preference: 0; Price: 0,0044999998062849; Price 2: 2,4300000667572; Price 3: 0; Published: 11.5.2011; Quantity: 1; ROHS: 1; Simulation: res; Stock: 985; Supplier: Digikey; Supplier2: Mouser Electronics; Supplier3: N/A; SupplierID: Digikey; SupplierID2: Mouser; SupplierID3: no supplier; SupplierURL: http://www.digikey.com/; SupplierURL2: www.mouser.com; SupplierURL3: N/A; Thermal Stability: 100ppm; Tolerance: 1%; Value: 10.0k; Publisher: Libor Polacek
R2-R4 = RES_000073: RES 0603 1.00k 1% 100ppm SMD thick film; Manufacturer: Panasonic; Manuf Part Number: ERJ3EKF1001V; Part Category: Thick film/0603; Part Select: SRES0001000300004100; Supp Part number: P1.00kHTR-ND; Supp Part number 2: 667-ERJ-3EKF1001V; Active: 1; Cat2: 0603; Database Table Name: _Resistors; Designer: Tomáš Košan; Exact price 2: 0; FIT: 0,100000001490116; ID: 434; LastRevisionNote: new release; Max Oper Temperature: 125; Min Oper Temperature: -55; PCB Status: Electrical; Power: 63mW; Alternative: 1; Cat1: Thick film; Component Kind: Standard; ComponentLink1Description: Datasheet; ComponentLink1URL: https://riceproject.fel.zcu.cz/Altium/PDF/passive\resistors\ERJ_EKF_Panasonic.pdf; Exact price: 1; Exaxt price 3: 0; LastRevisionDate: 11.5.2011; Library Name: Lib_Components_SQL.DbLib; ManufacturerID: Panasonic; ManufacturerURL: http://www.industrial.panasonic.com/; Part Number: RES_000073; PartNumber: RES_000073; PartQuantity: 1; Part type: RES; PCB3D: sm_res_0603; Preference: 0; Price: 0,0044999998062849; Price 2: 3,61999988555908; Price 3: 0; Published: 11.5.2011; Quantity: 1; ROHS: 1; Simulation: res; Stock: 2327; Supplier: Digikey; Supplier2: Mouser Electronics; Supplier3: N/A; SupplierID: Digikey; SupplierID2: Mouser; SupplierID3: no supplier; SupplierURL: http://www.digikey.com/; SupplierURL2: www.mouser.com; SupplierURL3: N/A; Thermal Stability: 100ppm; Tolerance: 1%; Value: 1.00k; Publisher: Libor Polacek
R7 R8 = RES_000081: RES 0603 2.20k 1% 100ppm SMD thick film; Manufacturer: Panasonic; Manuf Part Number: ERJ3EKF2201V; Part Category: Thick film/0603; Part Select: SRES0001000300004220; Supp Part number: P2.20kHTR-ND; Active: 1; Cat2: 0603; Database Table Name: _Resistors; Designer: LiPo; FIT: 0,100000001490116; ID: 442; LastRevisionNote: new release; Max Oper Temperature: 125; Min Oper Temperature: -55; PCB Status: Electrical; Power: 63mW; Alternative: 1; Cat1: Thick film; Component Kind: Standard; ComponentLink1Description: Datasheet; ComponentLink1URL: https://riceproject.fel.zcu.cz/Altium/PDF/passive\resistors\ERJ_EKF_Panasonic.pdf; Exact price: 1; LastRevisionDate: 11.5.2011; Library Name: Lib_Components_SQL.DbLib; ManufacturerID: Panasonic; ManufacturerURL: http://www.industrial.panasonic.com/; Part Number: RES_000081; PartNumber: RES_000081; PartQuantity: 1; Part type: RES; PCB3D: sm_res_0603; Price: 0,0044999998062849; Price 2: 0; Price 3: 0; Published: 11.5.2011; Quantity: 1; ROHS: 1; Simulation: res; Stock: 853; Supplier: Digikey; Supplier2: N/A; Supplier3: N/A; SupplierID: Digikey; SupplierID2: no supplier; SupplierID3: no supplier; SupplierURL: http://www.digikey.com/; SupplierURL2: N/A; SupplierURL3: N/A; Thermal Stability: 100ppm; Tolerance: 1%; Value: 2.20k; Publisher: Libor Polacek
V1 V2 = OPT_000003: OPT LED red 630nm 2mA 7,1mcd 0603; Manufacturer: OSRAM; Manuf Part Number: LS L29K-G1J2-1-Z; Part Category: LED/0603; Part Select: SOPT0001000100000000; Supp Part number: 475-2506-1-ND; Active: 1; Cat2: 0603; Database Table Name: _Optoelectronics; Designer: Tomáš Košan; Exact price: 0; FIT: 2; ID: 997; LastRevisionNote: new release; Max Oper Temperature: 100; Min Oper Temperature: -40; PCB Status: Electrical; Alternative: 1; Cat1: LED; Color: red; Component Kind: Standard; ComponentLink1Description: Datasheet; ComponentLink1URL: https://riceproject.fel.zcu.cz/Altium/PDF/Semiconductor\Analog\LED\LO_LS_LY_L29K_Pb_free.pdf; ComponentLink2URL: https://riceproject.fel.zcu.cz/Altium/PDF/; LastRevisionDate: 11.5.2011; Library Name: Lib_Components_SQL.DbLib; ManufacturerID: OSRAM; ManufacturerURL: http://www.osram.de/osram_de/; Part Number: OPT_000003; PartNumber: OPT_000003; PartQuantity: 1; Part type: OPT; PCB3D: sm_opt_led0603; Preference: 0; Price: 2,59999990463257; Price 2: 0; Price 3: 0; Published: 11.5.2011; Quantity: 1; ROHS: 0; Simulation: nosim; Stock: 152; Supplier: Digikey; Supplier2: N/A; Supplier3: N/A; SupplierID: Digikey; SupplierID2: no supplier; SupplierID3: no supplier; SupplierURL: http://www.digikey.com/; SupplierURL2: N/A; SupplierURL3: N/A; Value: L1R; Publisher: Libor Polacek
V3 = OPT_000005: OPT LED green 570nm 2mA 3,92mcd 0603; Manufacturer: OSRAM; Manuf Part Number: LG L29K-G2J1-24-Z; Part Category: LED/0603; Part Select: SOPT0001000100000000; Supp Part number: 475-2709-1-ND; Active: 1; Cat2: 0603; Database Table Name: _Optoelectronics; Designer: Tomáš Košan; Exact price: 0; FIT: 2; ID: 999; LastRevisionNote: new release; Max Oper Temperature: 100; Min Oper Temperature: -40; PCB Status: Electrical; Alternative: 1; Cat1: LED; Color: green; Component Kind: Standard; ComponentLink1Description: Datasheet; ComponentLink1URL: https://riceproject.fel.zcu.cz/Altium/PDF/Semiconductor\Analog\LED\LG_L29K_Pb_free.pdf; ComponentLink2URL: https://riceproject.fel.zcu.cz/Altium/PDF/; LastRevisionDate: 11.5.2011; Library Name: Lib_Components_SQL.DbLib; ManufacturerID: OSRAM; ManufacturerURL: http://www.osram.de/osram_de/; Part Number: OPT_000005; PartNumber: OPT_000005; PartQuantity: 1; Part type: OPT; PCB3D: sm_opt_led0603; Preference: 0; Price: 2,79999995231628; Price 2: 0; Price 3: 0; Published: 11.5.2011; Quantity: 1; ROHS: 0; Simulation: nosim; Stock: 212; Supplier: Digikey; Supplier2: N/A; Supplier3: N/A; SupplierID: Digikey; SupplierID2: no supplier; SupplierID3: no supplier; SupplierURL: http://www.digikey.com/; SupplierURL2: N/A; SupplierURL3: N/A; Value: L1G; Publisher: Libor Polacek
V4 = DIO_000074: DIO schottky  20V 0.5A SOD123; Manufacturer: On Semiconductor; ManufacturerID: OnSemi; Manuf Part Number: MBR0520LT1G; Part Select: SDIO0002000000000000; Supp Part number: MBR0520LCT-ND; Active: 1; Database Table Name: _Diodes; Designer: Libor Polacek; Exact price 2: 0; FIT: 2; ID: 4063; LastRevisionNote: new release; Max Oper Temperature: 125; Min Oper Temperature: -55; PCB Status: Electrical; Alternative: 1; Cat1: Schottky; Component Kind: Standard; ComponentLink1Description: Datasheet; ComponentLink1URL: https://riceproject.fel.zcu.cz/Altium/PDF/Semiconductor\Analog\Diode\MBR0520LT1-D.PDF; Current: 0.5A; Exact price: 1; Exaxt price 3: 0; LastRevisionDate: 11.5.2011; Library Name: Lib_Components_SQL.DbLib; ManufacturerURL: http://www.onsemi.com; Part Category: Schottky; Part Number: DIO_000074; PartNumber: DIO_000074; PartQuantity: 1; Part type: DIO; Preference: 0; Price: 7; Price 2: 0; Price 3: 0; Published: 11.5.2011; ROHS: 1; Simulation: nosim; Stock: 0; Supplier: Digikey; Supplier2: N/A; Supplier3: N/A; SupplierID: Digikey; SupplierID2: no supplier; SupplierID3: no supplier; SupplierURL: http://www.digikey.com/; SupplierURL2: N/A; SupplierURL3: N/A; Value: MBR0520LT; Voltage: 20V; Publisher: Libor Polacek
